# Altium schematic sheet Sheet1.SchDoc
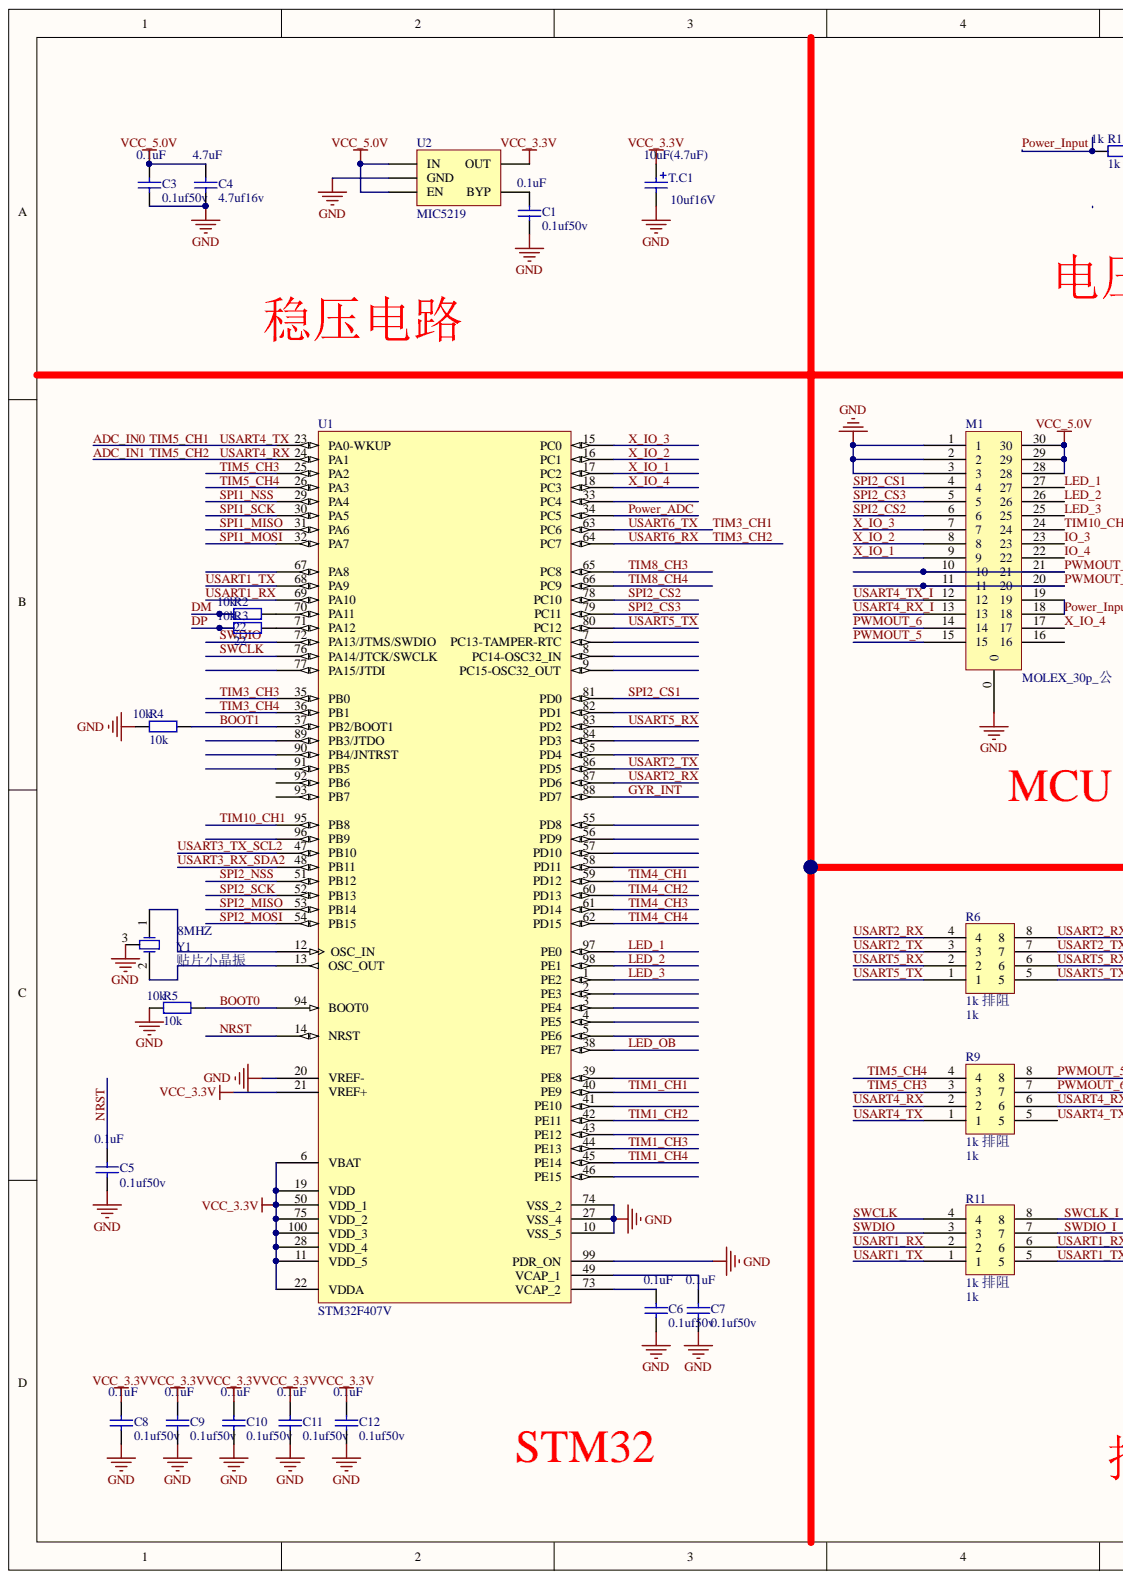
[diagram p0: Sheet1.SchDoc, left]
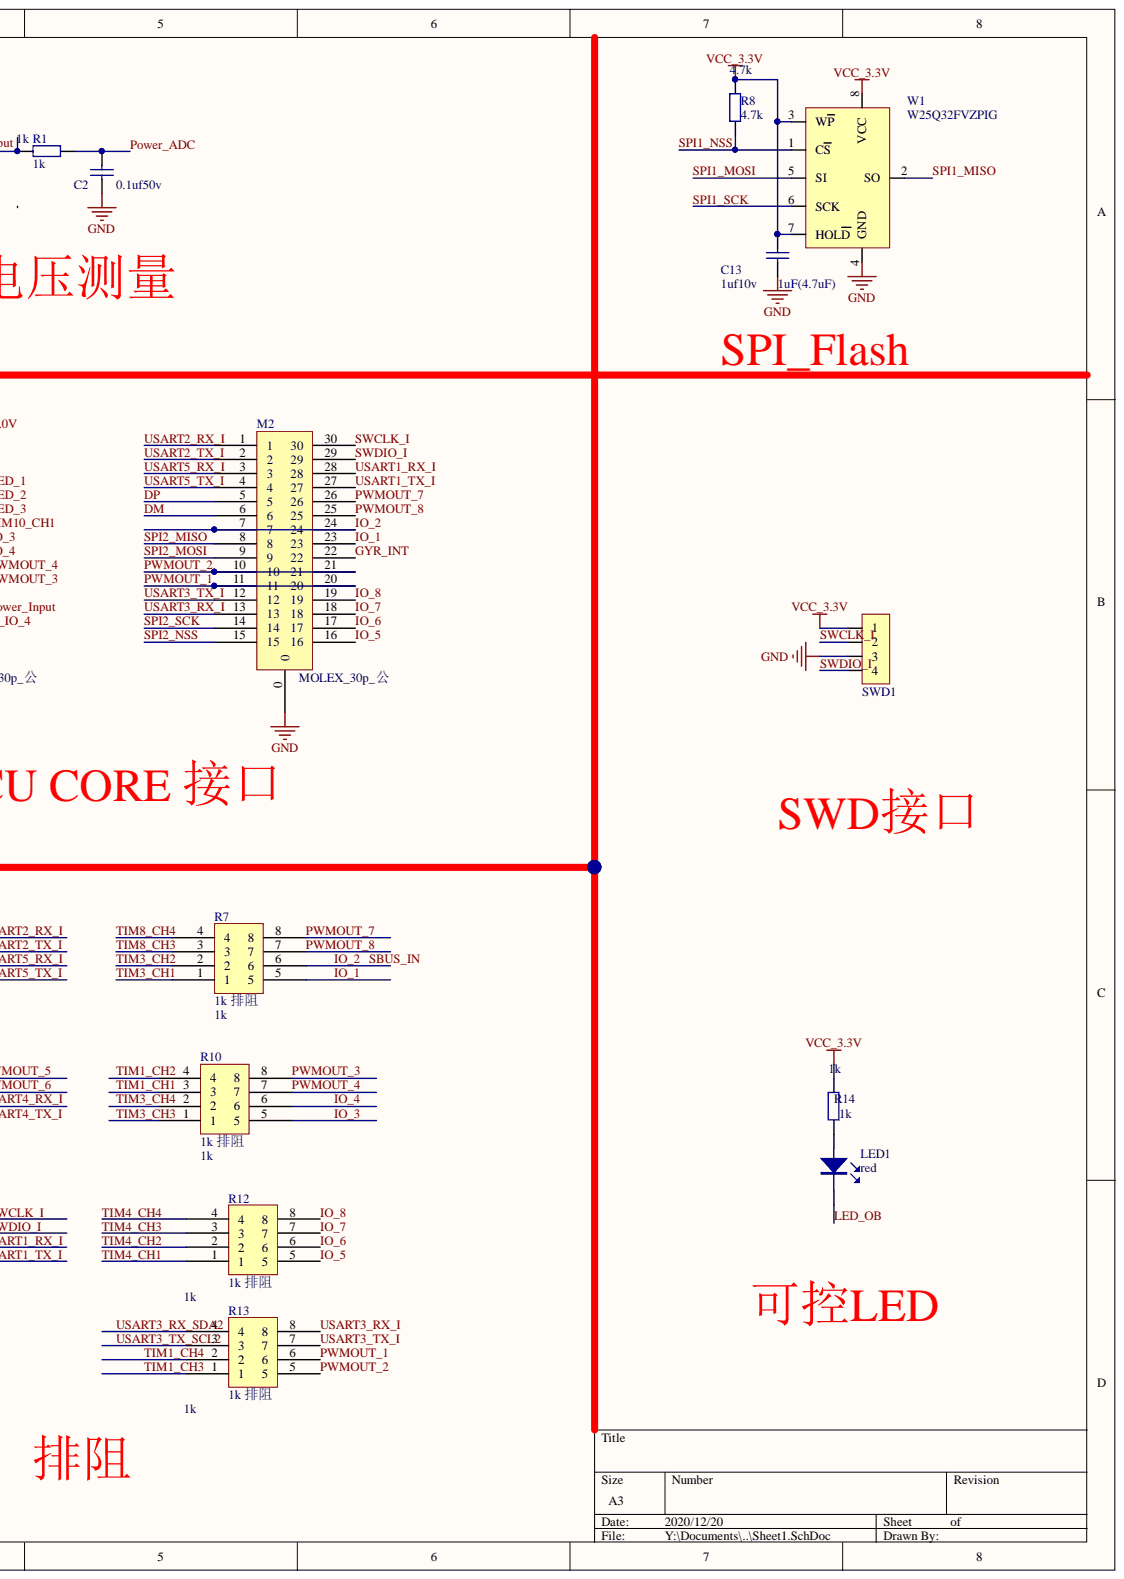
[diagram p1: Sheet1.SchDoc, right]

## Parts
C1  0.1uf50v  value 0.1uF  [0402_small]
C2  0.1uf50v  [0402_small]
C3  0.1uf50v  value 0.1uF  [0402_small]
C4  4.7uf16v  value 4.7uF  [1206_C]
C5  0.1uf50v  value 0.1uF  [0402_small]
C6  0.1uf50v  value 0.1uF  [0402_small]
C7  0.1uf50v  value 0.1uF  [0402_small]
C8  0.1uf50v  value 0.1uF  [0402_small]
C9  0.1uf50v  value 0.1uF  [0402_small]
C10  0.1uf50v  value 0.1uF  [0402_small]
C11  0.1uf50v  value 0.1uF  [0402_small]
C12  0.1uf50v  value 0.1uF  [0402_small]
C13  1uf10v  value 1uF(4.7uF)  [0402_small]
LED1  red  [0402_small]
M1  MOLEX_30p_公  [MOLEX_30p_公]
M2  MOLEX_30p_公  [MOLEX_30p_公]
R1  1k  [0402_small]
R2  22  [0402_small]
R3  22  [0402_small]
R4  10k  [0402_small]
R5  10k  [0402_small]
R6  1k 排阻  value 1k  [4D02]
R7  1k 排阻  value 1k  [4D02]
R8  4.7k  [0402_small]
R9  1k 排阻  value 1k  [4D02]
R10  1k 排阻  value 1k  [4D02]
R11  1k 排阻  value 1k  [4D02]
R12  1k 排阻  value 1k  [4D02]
R13  1k 排阻  value 1k  [4D02]
R14  1k  [0402_small]
SWD1  HDR1X4_180_2.54  [HEADER_1X4_T_1.27]  (value not drawn)
T.C1  10uf16V  value 10uF(4.7uF)  [TAN-A(3216-18)]
U1  STM32F407V  [LQFP100_N]
U2  MIC5219  [SOT23-5]
W1  W25Q32FVZPIG  [8-WSON]
Y1  贴片小晶振  value 8MHZ  [XTAL_3P]

## Nets
GND: C1.2 C2.1 C3.2 C4.2 C5.2 C6.2 C7.2 C8.2 C9.2 C10.2 C11.2 C12.2 C13.2 M1.0 M1.1 M1.2 M1.3 M2.0 R4.2 R5.2 SWD1.3 T.C1.2 U1.10(VSS_5) U1.20(VREF-) U1.27(VSS_4) U1.74(VSS_2) U1.99(PDR_ON) U2.2(GND) W1.4(GND) Y1.3
VCC_3.3V: C8.1 C9.1 C10.1 C11.1 C12.1 C13.1 R8.2 R14.2 SWD1.1 T.C1.1 U1.6(VBAT) U1.11(VDD_5) U1.19(VDD) U1.21(VREF+) U1.22(VDDA) U1.28(VDD_4) U1.50(VDD_1) U1.75(VDD_2) U1.100(VDD_3) U2.5(OUT) W1.3(WP) W1.7(HOLD) W1.8(VCC)
VCC_5.0V: C3.1 C4.1 M1.28 M1.29 M1.30 U2.1(IN) U2.3(EN)
ADC_IN0: R9.1 U1.23(PA0-WKUP)
ADC_IN1: R9.2 U1.24(PA1)
BOOT0: R5.1 U1.94(BOOT0)
BOOT1: R4.1 U1.37(PB2/BOOT1)
DM: M2.6 R2.2
DP: M2.5 R3.2
GYR_INT: M2.22 U1.88(PD7)
IO_1: M2.23 R7.5
IO_2: M2.7 M2.24 R7.6
IO_3: M1.23 R10.5
IO_4: M1.22 R10.6
IO_5: M2.16 R12.5
IO_6: M2.17 R12.6
IO_7: M2.18 R12.7
IO_8: M2.19 R12.8
LED_1: M1.27 U1.97(PE0)
LED_2: M1.26 U1.98(PE1)
LED_3: M1.25 U1.1(PE2)
LED_OB: LED1.2 U1.38(PE7)
NRST: C5.1 U1.14(NRST)
Power_ADC: C2.2 R1.1 U1.34(PC5)
Power_Input: M1.18 M1.19 R1.2
PWMOUT_1: M2.11 M2.20 R13.6
PWMOUT_2: M2.10 M2.21 R13.5
PWMOUT_3: M1.11 M1.20 R10.8
PWMOUT_4: M1.10 M1.21 R10.7
PWMOUT_5: M1.15 R9.8
PWMOUT_6: M1.14 R9.7
PWMOUT_7: M2.26 R7.8
PWMOUT_8: M2.25 R7.7
SPI1_MISO: U1.31(PA6) W1.2(SO)
SPI1_MOSI: U1.32(PA7) W1.5(SI)
SPI1_NSS: R8.1 U1.29(PA4) W1.1(CS)
SPI1_SCK: U1.30(PA5) W1.6(SCK)
SPI2_CS1: M1.4 U1.81(PD0)
SPI2_CS2: M1.6 U1.78(PC10)
SPI2_CS3: M1.5 U1.79(PC11)
SPI2_MISO: M2.8 U1.53(PB14)
SPI2_MOSI: M2.9 U1.54(PB15)
SPI2_NSS: M2.15 U1.51(PB12)
SPI2_SCK: M2.14 U1.52(PB13)
SWCLK: R11.4 U1.76(PA14/JTCK/SWCLK)
SWCLK_I: M2.30 R11.8 SWD1.2
SWDIO: R11.3 U1.72(PA13/JTMS/SWDIO)
SWDIO_I: M2.29 R11.7 SWD1.4
TIM1_CH1: R10.3 U1.40(PE9)
TIM1_CH2: R10.4 U1.42(PE11)
TIM1_CH3: R13.1 U1.44(PE13)
TIM1_CH4: R13.2 U1.45(PE14)
TIM3_CH1: R7.1 U1.63(PC6)
TIM3_CH2: R7.2 U1.64(PC7)
TIM3_CH3: R10.1 U1.35(PB0)
TIM3_CH4: R10.2 U1.36(PB1)
TIM4_CH1: R12.1 U1.59(PD12)
TIM4_CH2: R12.2 U1.60(PD13)
TIM4_CH3: R12.3 U1.61(PD14)
TIM4_CH4: R12.4 U1.62(PD15)
TIM5_CH3: R9.3 U1.25(PA2)
TIM5_CH4: R9.4 U1.26(PA3)
TIM8_CH3: R7.3 U1.65(PC8)
TIM8_CH4: R7.4 U1.66(PC9)
TIM10_CH1: M1.24 U1.95(PB8)
USART1_RX: R11.2 U1.69(PA10)
USART1_RX_I: M2.28 R11.6
USART1_TX: R11.1 U1.68(PA9)
USART1_TX_I: M2.27 R11.5
USART2_RX: R6.4 U1.87(PD6)
USART2_RX_I: M2.1 R6.8
USART2_TX: R6.3 U1.86(PD5)
USART2_TX_I: M2.2 R6.7
USART3_RX_I: M2.13 R13.8
USART3_RX_SDA2: R13.4 U1.48(PB11)
USART3_TX_I: M2.12 R13.7
USART3_TX_SCL2: R13.3 U1.47(PB10)
USART4_RX_I: M1.13 R9.6
USART4_TX_I: M1.12 R9.5
USART5_RX: R6.2 U1.83(PD2)
USART5_RX_I: M2.3 R6.6
USART5_TX: R6.1 U1.80(PC12)
USART5_TX_I: M2.4 R6.5
X_IO_1: M1.9 U1.17(PC2)
X_IO_2: M1.8 U1.16(PC1)
X_IO_3: M1.7 U1.15(PC0)
X_IO_4: M1.17 U1.18(PC3)
NetC1_1: C1.1 U2.4(BYP)
NetC6_1: C6.1 U1.73(VCAP_2)
NetC7_1: C7.1 U1.49(VCAP_1)
NetLED1_1: LED1.1 R14.1
NetR2_1: R2.1 U1.70(PA11)
NetR3_1: R3.1 U1.71(PA12)
NetU1_12: U1.12(OSC_IN) Y1.1
NetU1_13: U1.13(OSC_OUT) Y1.2
N00000:

Not connected: M1 16; U1 2(PE3) 3(PE4) 4(PE5) 5(PE6) 7(PC13-TAMPER-RTC) 8(PC14-OSC32_IN) 9(PC15-OSC32_OUT) 33(PC4) 39(PE8) 41(PE10) 43(PE12) 46(PE15) 55(PD8) 56(PD9) 57(PD10) 58(PD11) 67(PA8) 77(PA15/JTDI) 82(PD1) 84(PD3) 85(PD4) 89(PB3/JTDO) 90(PB4/JNTRST) 91(PB5) 92(PB6) 93(PB7) 96(PB9)

## Library data of the parts
C1 C3-C13 = Cap Semi: Capacitor (Semiconductor SIM Model); Component Kind: Standard; LatestRevisionDate: 17-Jul-2002; LatestRevisionNote: Re-released for DXP Platform.; Library Name: Miscellaneous Devices.IntLib; PackageReference: C1206; Published: 8-Jun-2000; Simulation: CAP; Publisher: Altium Limited
C2 = Cap Semi: Capacitor (Semiconductor SIM Model); value: 0.1uF; Component Kind: Standard; LatestRevisionDate: 17-Jul-2002; LatestRevisionNote: Re-released for DXP Platform.; Library Name: Miscellaneous Devices.IntLib; PackageReference: C1206; Published: 8-Jun-2000; Simulation: CAP; Publisher: Altium Limited
LED1 = D-LED: LED; Component Kind: Standard; Library Name: RCDQLY.SCHLIB
R1 R4 R5 R8 R14 = Res: Resistor; Component Kind: Standard; LatestRevisionDate: 17-Jul-2002; LatestRevisionNote: Re-released for DXP Platform.; PackageReference: AXIAL-0.4; Published: 8-Jun-2000; Simulation: RESISTOR; Library Name: SZG.SCHLIB; Publisher: Altium Limited
  R1 R14: Value: 1k
  R4 R5: Value: 10k
  R8: Value: 4.7k
R2 R3 = Res: Resistor; Value: 10k; Component Kind: Standard; LatestRevisionDate: 17-Jul-2002; LatestRevisionNote: Re-released for DXP Platform.; PackageReference: AXIAL-0.4; Published: 8-Jun-2000; Simulation: RESISTOR; Library Name: SZG.SCHLIB; Publisher: Altium Limited
R6 R7 R9-R13 = RES*4: Component Kind: Standard; Library Name: 6050.SchLib
SWD1 = HDR1X4_180_2.54: Header; Component Kind: Standard; Library Name: SCH_Connector.SchLib
T.C1 = Cap TAN: TAN Capacitor (Radial); Component Kind: Standard; LatestRevisionDate: 17-Jul-2002; LatestRevisionNote: Re-released for DXP Platform.; Library Name: FCSchlib.SchLib; PackageReference: RB7.6-15; Published: 8-Jun-2000; Simulation: CAP; Publisher: Altium Limited
U1 = STM32F407VG: STM32 ARM-based 32-bit MCU with 1024 Kbytes Flash, 100-pin LQFP, Industrial Temperature; Component Kind: Standard; ComponentLink1Description: Manufacturer Link; ComponentLink1URL: www.st.com/stm32; ComponentLink2Description: Datasheet; ComponentLink2URL: http://www.st.com/stonline/products/literature/ds/13587.pdf; DatasheetVersion: rev6, Mar-2008; Library Name: STM32F407VGT6.SchLib; PackageDescription: 100-Pin Low-Profile Quad Flat Pack, 14 x 14 mm Body, 0.5 mm Pitch (LQFP100); PackageReference: LQFP100; PackageVersion: Mar-2008; Published: 30-May-2008; Publisher: Altium Limited
U2 = MIC5205: MIC5205同TPS79330; Component Kind: Standard; Library Name: FCSchlib.SchLib
Y1 = XTAL 贴片小: Component Kind: Standard; Library Name: haosite.SchLib
M1 M2 = MOLEX_30p_公: Component Kind: Standard; Library Name: My_lib_wjt.SchLib
W1 = W25Q32FVZPIG: Component Kind: Standard; Library Name: 原理.SchLib
